# Altium schematic sheet Schema_Volante.SchDoc(Schema_Volante)
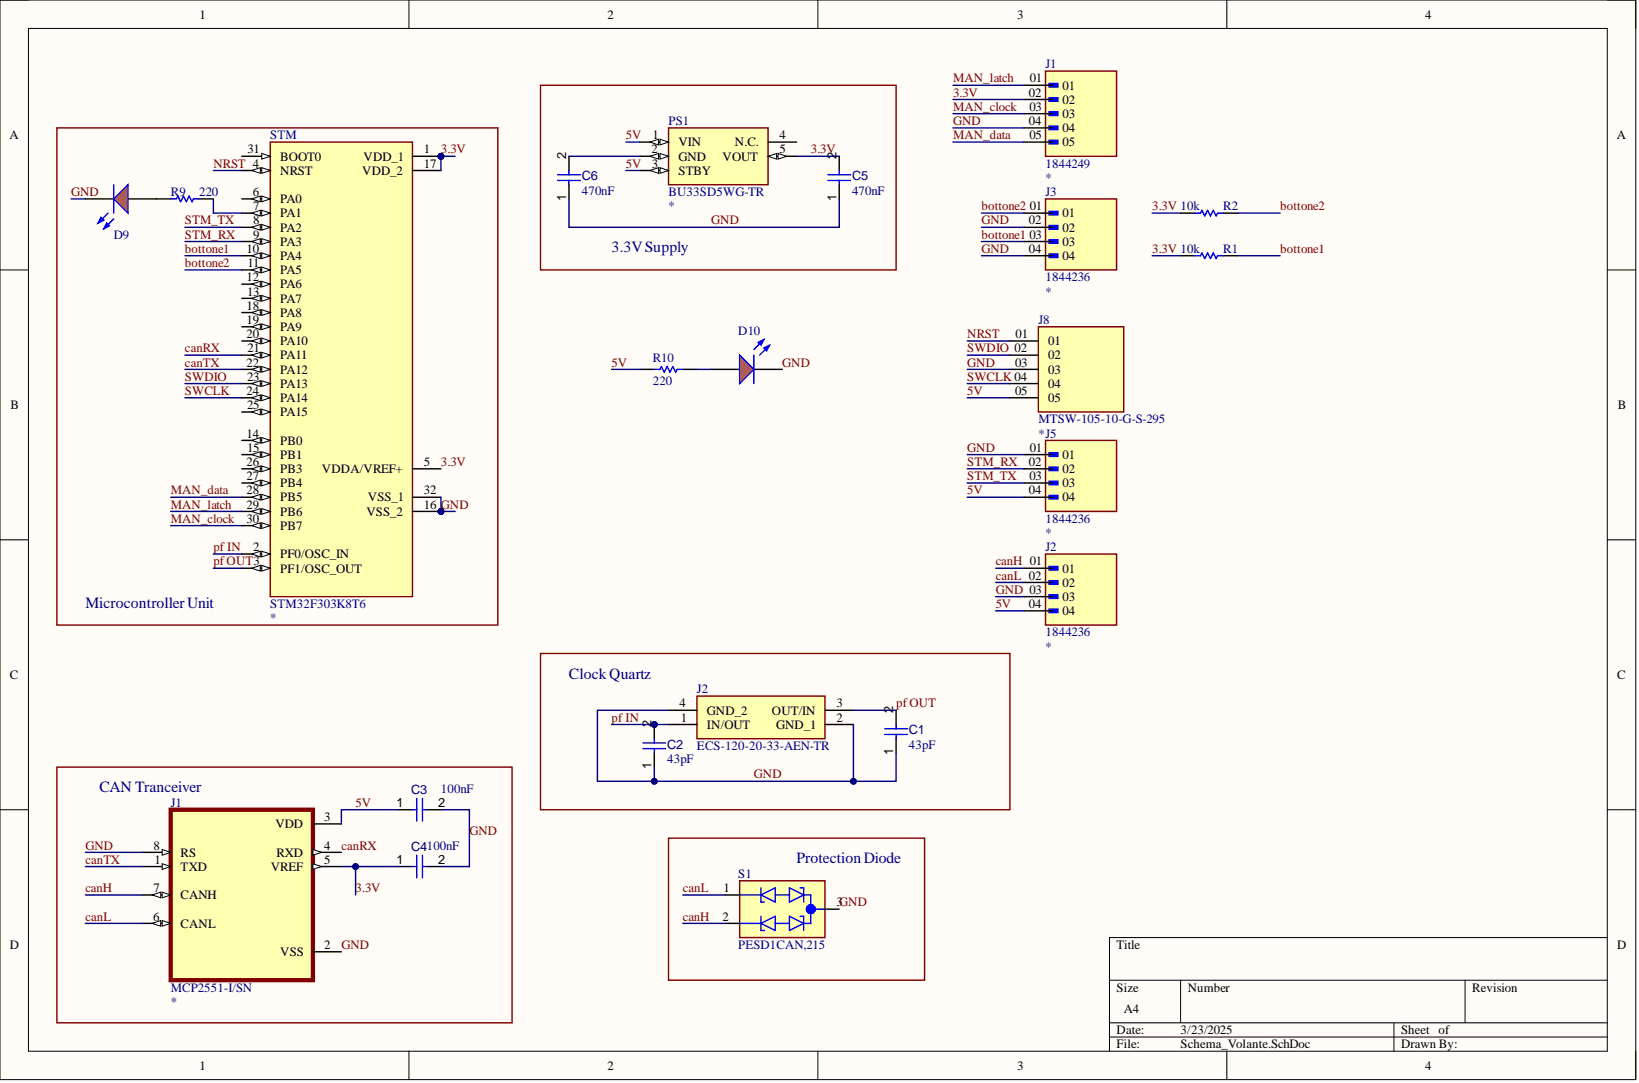
[diagram p0: Schema_Volante.SchDoc]

## Parts
C1  value 43pF  (hidden comment: Capacitor 1 uF +/- 10% 25 V 0603)
C2  value 43pF  (hidden comment: Capacitor 1 uF +/- 10% 25 V 0603)
C3  value 100nF  (hidden comment: Capacitor 1 uF +/- 10% 25 V 0603)
C4  value 100nF  (hidden comment: Capacitor 1 uF +/- 10% 25 V 0603)
C5  value 470nF  (hidden comment: Capacitor 1 uF +/- 10% 25 V 0603)
C6  value 470nF  (hidden comment: Capacitor 1 uF +/- 10% 25 V 0603)
D9  HSMD-C190  (value not drawn)
D10  HSMD-C190  (value not drawn)
J1  1844249
J1  MCP2551-I/SN
J2  1844236
J2  ECS-120-20-33-AEN-TR
J3  1844236
J5  1844236
J8  MTSW-105-10-G-S-295
PS1  BU33SD5WG-TR
R1  value 10k  (hidden comment: Resistor 1k +/-1% 0402 100 mW)
R2  value 10k  (hidden comment: Resistor 1k +/-1% 0402 100 mW)
R9  value 220  (hidden comment: Resistor 1k +/-1% 0402 100 mW)
R10  value 220  (hidden comment: Resistor 1k +/-1% 0402 100 mW)
S1  PESD1CAN,215
STM  STM32F303K8T6

## Nets
3.3V: C4.1 C5.2 J1.02 J1.5(VREF) PS1.5(VOUT) R1.1 R2.1 STM.1(VDD_1) STM.5(VDDA/VREF+) STM.17(VDD_2)
5V: C3.1 J1.3(VDD) J2.04 J5.04 J8.05 PS1.1(VIN) PS1.3(STBY) R10.1
bottone1: J3.03 R1.2 STM.10(PA4)
bottone2: J3.01 R2.2 STM.11(PA5)
canH: J1.7(CANH) J2.01 S1.2
canL: J1.6(CANL) J2.02 S1.1
canRX: J1.4(RXD) STM.21(PA11)
canTX: J1.1(TXD) STM.22(PA12)
GND: C1.1 C2.1 C3.2 C4.2 C5.1 C6.1 D9.2 D10.2 J1.2(VSS) J1.04 J1.8(RS) J2.2(GND_1) J2.03 J2.4(GND_2) J3.02 J3.04 J5.01 J8.03 S1.3 STM.16(VSS_2) STM.32(VSS_1)
MAN_clock: J1.03 STM.30(PB7)
MAN_data: J1.05 STM.28(PB5)
MAN_latch: J1.01 STM.29(PB6)
NRST: J8.01 STM.4(NRST)
pf IN: C2.2 J2.1(IN/OUT) STM.2(PF0/OSC_IN)
pf OUT: C1.2 J2.3(OUT/IN) STM.3(PF1/OSC_OUT)
STM_RX: J5.02 STM.9(PA3)
STM_TX: J5.03 STM.8(PA2)
SWCLK: J8.04 STM.24(PA14)
SWDIO: J8.02 STM.23(PA13)
NetC6_2: C6.2 PS1.2(GND)
NetD9_1: D9.1 R9.1
NetD10_1: D10.1 R10.2
NetR9_2: R9.2 STM.7(PA1)

Not connected: PS1 4(N.C.); STM 6(PA0) 12(PA6) 13(PA7) 14(PB0) 15(PB1) 18(PA8) 19(PA9) 20(PA10) 25(PA15) 26(PB3) 27(PB4) 31(BOOT0)

## Library data of the parts
C1-C6 = CMP-001-00005-6: Chip Capacitor, 1 uF, +/- 10%, 25 V, 0603 (1608 Metric); Capacitor Type: Ceramic; Voltage Rating: 25V; Case/Package: 0603; Component Kind: Standard; Max Operating Temperature: 125°C; Min Operating Temperature: -55°C; Mounting Technology: SM; Pins: 2; RoHS Compliant: True; Tolerance: 10%; Library Name: Polimarche Racing Team- Polytechnic University of Marche
D9 D10 = CMP-007-00012-2: LED Uni-Color Orange, 52 mW, 20 mA, -40 to 85 degC, 2-Pin SMD, RoHS, Tape and Reel; Manufacturer: Avago; Forward Current: 20mA; Forward Voltage: 2.2V; Lens Style: Diffused; Luminous Intensity: 8mcd; Power: 52mW; Viewing Angle: 170degrees; Case/Package: 0603; Colour: Orange; Component Kind: Standard; Datasheet URL: http://docs.avagotech.com/docs/AV02-0551EN; Datasheet Version: Mar-12; Illumination Colour: Orange; Manufacturer URL: http://www.avagotech.com/; Max Operating Temperature: 85°C; Min Operating Temperature: -40°C; Mounting Technology: SM; Nominal Vf: 2.2V; Package Description: 2-Pin Surface Mount Device, Body 1.6 x 0.8 mm, Height 0.9 mm; Package Quantity: 4000; Package Reference: HSMX-C190; Packaging: TapeandReel; Pins: 2; RoHS Compliant: True; Library Name: Polimarche Racing Team- Polytechnic University of Marche
J1 = CMP-090-000000-1: MF: {'manufacturer': u'Phoenix Contact'}; Availability: Unavailable; Component Kind: Standard; Component Type: Standard; Design Item ID: 1844249; MP: 1844249; Package: None; Pin Count: 5; Price: None; Source: 1844249.SchLib; Library Name: Polimarche Racing Team- Polytechnic University of Marche
J1 = CMP-075-000000-1: Availability: Unavailable; Component Kind: Standard; Component Type: Standard; Design Item ID: MCP2551-I/SN; MP: MCP2551-I/SN; Package: None; Pin Count: 8; Price: None; Source: MCP2551-I_SN.SchLib; Library Name: Polimarche Racing Team- Polytechnic University of Marche
J2 J3 J5 = CMP-086-000000-1: MF: {'manufacturer': u'Phoenix Contact'}; Availability: Unavailable; Component Kind: Standard; Component Type: Standard; Design Item ID: 1844236; MP: 1844236; Package: None; Pin Count: 4; Price: None; Source: 1844236.SchLib; Library Name: Polimarche Racing Team- Polytechnic University of Marche
J2 = CMP-067-000000-1: Crystals 12MHz 25ppm 20pF -40C +85C; Manufacturer_Name: ECS; Geometry.Height: 0.8mm; Component Kind: Standard; Datasheet Link: https://www.arrow.com/en/products/ecs-120-20-33-aen-tr/ecs-international; Design Item ID: ECS-120-20-33-AEN-TR; Manufacturer_Part_Number: ECS-120-20-33-AEN-TR; Mouser Part Number: 520-120-20-33-AENT; Mouser Price/Stock: https://www.mouser.co.uk/ProductDetail/ECS/ECS-120-20-33-AEN-TR?qs=wd5RIQLrsJjPkutOht3eOA%3D%3D; Source: ECS-120-20-33-AEN-TR.SchLib; Library Name: Polimarche Racing Team- Polytechnic University of Marche
J8 = CMP-088-000000-1: Availability: Unavailable; Component Kind: Standard; Component Type: Standard; Design Item ID: MTSW-105-10-G-S-295; MP: MTSW-105-10-G-S-295; Package: None; Pin Count: 5; Price: None; Source: MTSW-105-10-G-S-295.SchLib; Library Name: Polimarche Racing Team- Polytechnic University of Marche
PS1 = CMP-064-000000-1: Linear Voltage Regulator IC Positive Fixed 1 Output 500mA 5-SSOP; MF: Rohm; Check_prices: https://www.snapeda.com/parts/BU33SD5WG-TR/Rohm/view-part/?ref=eda; Component Kind: Standard; Component Type: Standard; Design Item ID: BU33SD5WG-TR; MP: BU33SD5WG-TR; Package: SSOP-5 Rohm; Pin Count: 5; SnapEDA_Link: https://www.snapeda.com/parts/BU33SD5WG-TR/Rohm/view-part/?ref=snap; Source: BU33SD5WG-TR.SchLib; Library Name: Polimarche Racing Team- Polytechnic University of Marche
R1 R2 R9 R10 = CMP-009-00009-5: Chip Resistor, 1 KOhm, +/- 1%, 0.1 W, -55 to 155 degC, 0402 (1005 Metric); Power: 100mW; Voltage Rating: 50V; Case/Package: 0402; Component Kind: Standard; Max Operating Temperature: 155°C; Min Operating Temperature: -55°C; Mounting Technology: SM; Pins: 2; RoHS Compliant: True; Tolerance: 1%; Library Name: Polimarche Racing Team- Polytechnic University of Marche
S1 = CMP-074-000000-1: 70V Clamp 3A (8/20Âµs) Ipp Tvs Diode Surface Mount TO-236AB; MF: Nexperia USA Inc.; Check_prices: https://www.snapeda.com/parts/PESD1CAN,215/Nexperia/view-part/?ref=eda; Component Kind: Standard; Component Type: Standard; Design Item ID: PESD1CAN,215; MP: PESD1CAN,215; Package: SC-59 Nexperia USA; Pin Count: 3; SnapEDA_Link: https://www.snapeda.com/parts/PESD1CAN,215/Nexperia/view-part/?ref=snap; Source: PESD1CAN,215.SchLib; Library Name: Polimarche Racing Team- Polytechnic University of Marche
STM = CMP-062-000000-1: ARMÂ® CortexÂ®-M4 STM32F3 Microcontroller IC 32-Bit 72MHz 64KB _64K x 8_ FLASH 32-LQFP _7x7_; MF: STMicroelectronics; Availability: Unavailable; Component Kind: Standard; Component Type: Standard; Design Item ID: STM32F303K8T6; MP: STM32F303K8T6; Package: LQFP-32 STMicroelectronics; Pin Count: 32; Price: None; SnapEDA_Link: https://www.snapeda.com/parts/STM32F303K8T6/STMicroelectronics/view-part/473338/?ref=snap; Source: SnapEDAsch.SchLib; Library Name: Polimarche Racing Team- Polytechnic University of Marche
